# Altium schematic sheet Sheet2.SchDoc(Sheet2)
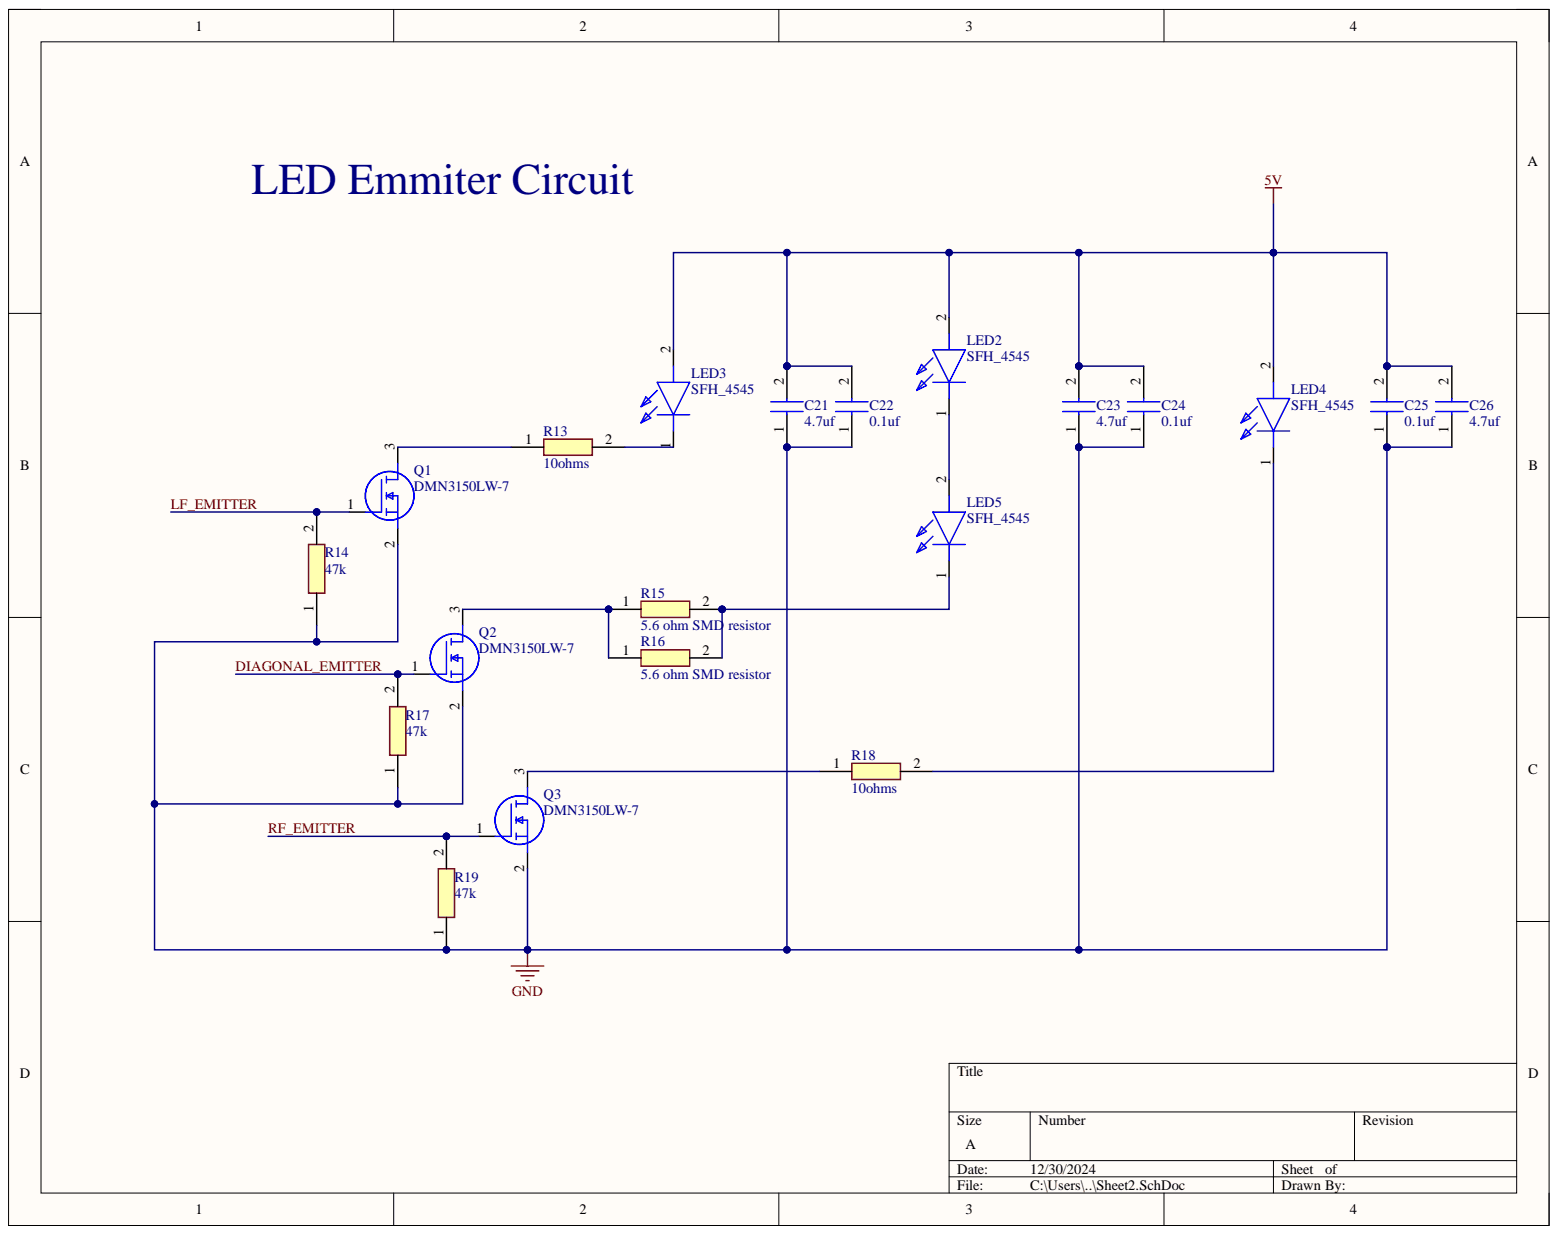
[diagram p0: Sheet2.SchDoc]

## Parts
C21  4.7uf  [CAPC1005X55N]
C22  0.1uf  [CAPC1005X55N]
C23  4.7uf  [CAPC1005X55N]
C24  0.1uf  [CAPC1005X55N]
C25  0.1uf  [CAPC1005X55N]
C26  4.7uf  [CAPC1005X55N]
LED2  SFH_4545  [SFH4545]
LED3  SFH_4545  [SFH4545]
LED4  SFH_4545  [SFH4545]
LED5  SFH_4545  [SFH4545]
Q1  DMN3150LW-7  [DMN3150LW]
Q2  DMN3150LW-7  [DMN3150LW]
Q3  DMN3150LW-7  [SOT65P210X110-3N]
R13  10ohms  [RESC6432X120N]
R14  47k  [RESC1005X40N]
R15  5.6 ohm SMD resistor  [5.6ohm SMD]
R16  5.6 ohm SMD resistor  [5.6ohm SMD]
R17  47k  [RESC1005X40N]
R18  10ohms  [RESC6432X120N]
R19  47k  [RESC1005X40N]

## Nets
5V: C21.2 C22.2 C23.2 C24.2 C25.2 C26.2 LED2.2 LED3.2 LED4.2
GND: C21.1 C22.1 C23.1 C24.1 C25.1 C26.1 Q1.2 Q2.2 Q3.2 R14.1 R17.1 R19.1
DIAGONAL_EMITTER: Q2.1 R17.2
LF_EMITTER: Q1.1 R14.2
RF_EMITTER: Q3.1 R19.2
NetLED2_1: LED2.1 LED5.2
NetLED3_1: LED3.1 R13.2
NetLED4_1: LED4.1 R18.2
NetLED5_1: LED5.1 R15.2 R16.2
NetQ1_3: Q1.3 R13.1
NetQ2_3: Q2.3 R15.1 R16.1
NetQ3_3: Q3.3 R18.1

## Library data of the parts
C21 C23 C26 = CM05X5R475M16AH: 4.7uf SMD ceramic; Manufacturer_Name: Kyocera AVX; Component Kind: Standard; Datasheet Link: https://datasheets.kyocera-avx.com/cx5r-KGM.pdf; Height: 0.7mm; Library Name: Schlib1.SchLib; Manufacturer_Part_Number: CM05X5R475M16AH; Mouser Part Number: 581-CM05X5R475M16AH; Mouser Price/Stock: https://www.mouser.co.uk/ProductDetail/KYOCERA-AVX/CM05X5R475M16AH?qs=doiCPypUmgFT1xquZKhWtQ%3D%3D
C22 C24 C25 = MBAST105SB7104KFNA01: 0.1uf SMD 25V cermaic; Manufacturer_Name: TAIYO YUDEN; Component Kind: Standard; Datasheet Link: https://ds.yuden.co.jp/TYCOMPAS/or/specSheet?pn=MBAST105SB7104KFNA01; Height: 0.55mm; Library Name: Schlib1.SchLib; Manufacturer_Part_Number: MBAST105SB7104KFNA01; Mouser Part Number: 963-BAST105SB7104KF; Mouser Price/Stock: https://www.mouser.co.uk/ProductDetail/TAIYO-YUDEN/MBAST105SB7104KFNA01?qs=tlsG%2FOw5FFj0FpJuqULGiw%3D%3D
LED2-LED5 = SFH_4545: LED; Manufacturer_Name: ams OSRAM; Arrow Part Number: SFH 4545; Arrow Price/Stock: https://www.arrow.com/en/products/sfh4545/osram-opto-semiconductors; Component Kind: Standard; Datasheet Link: https://datasheet.datasheetarchive.com/originals/distributors/DKDS41/DSANUWW0036915.pdf; Height: 8.3mm; Manufacturer_Part_Number: SFH 4545; Mouser Part Number: 720-SFH4545; Mouser Price/Stock: https://www.mouser.co.uk/ProductDetail/ams-OSRAM/SFH-4545?qs=VcOkZLzzaubOJpq6Xyji1A%3D%3D
  LED3-LED5: Library Name: Schlib1.SchLib
Q1-Q3 = DMN3150LW-7: MOSFET (N-Channel); Manufacturer_Name: Diodes Incorporated; Arrow Part Number: DMN3150LW-7; Arrow Price/Stock: https://www.arrow.com/en/products/dmn3150lw-7/diodes-incorporated; Component Kind: Standard; Datasheet Link: https://www.diodes.com/assets/Datasheets/ds31514.pdf; Height: 1.1mm; Manufacturer_Part_Number: DMN3150LW-7; Mouser Part Number: 621-DMN3150LW-7; Mouser Price/Stock: https://www.mouser.co.uk/ProductDetail/Diodes-Incorporated/DMN3150LW-7?qs=ptj1V1atRAqvGijpY%2FDU4Q%3D%3D
  Q1 Q2: Library Name: Schlib1.SchLib
R13 R18 = 352210RFT: 10 ohm 1% thick SMD 3W; Manufacturer_Name: TE Connectivity; Arrow Part Number: 352210RFT; Arrow Price/Stock: https://www.arrow.com/en/products/352210rft/te-connectivity?region=nac; Component Kind: Standard; Datasheet Link: https://www.te.com/commerce/DocumentDelivery/DDEController?Action=srchrtrv&DocNm=9-1773463-7&DocType=Data%20Sheet&DocLang=English&PartCntxt=2176231-1&DocFormat=pdf; Height: 1.2mm; Library Name: Schlib1.SchLib; Manufacturer_Part_Number: 352210RFT; Mouser Part Number: 279-352210RFT; Mouser Price/Stock: https://www.mouser.co.uk/ProductDetail/TE-Connectivity-Holsworthy/352210RFT?qs=ojKcPFmCWSV5LyIb%252BwzFvQ%3D%3D
R14 R17 R19 = RN73H1ETTP4702F50: 47kohm 1% 50v SMD thin; Manufacturer_Name: KOA Speer; Component Kind: Standard; Datasheet Link: https://datasheet.datasheetarchive.com/originals/distributors/Datasheets_SAMA/d16ea0929aca8c9bbbb37f20c24edc3e.pdf; Height: 0.4mm; Library Name: Schlib1.SchLib; Manufacturer_Part_Number: RN73H1ETTP4702F50; Mouser Part Number: 660-RN73H1ETP4702F50; Mouser Price/Stock: https://www.mouser.co.uk/ProductDetail/KOA-Speer/RN73H1ETTP4702F50?qs=9tvNVxbbcEof3GV0enxvDw%3D%3D
R15 R16 = 5.6 ohm SMD resistor: Resistor; Manufacturer_Name: TE Connectivity; Manufacturer_Part_Number: 35225R6JT; Arrow Part Number: 35225R6JT; Arrow Price/Stock: https://www.arrow.com/en/products/35225r6jt/te-connectivity?region=nac; Component Kind: Standard; Datasheet Link: https://componentsearchengine.com/Datasheets/1/35225R6JT.pdf; Height: 1.2mm; Library Name: Schlib1.SchLib; Mouser Part Number: 279-35225R6JT; Mouser Price/Stock: https://www.mouser.co.uk/ProductDetail/TE-Connectivity-Holsworthy/35225R6JT?qs=Rv6LVDxB0ZovQxl8JKhp1g%3D%3D
